# Altium schematic sheet DimmerModule.SchDoc
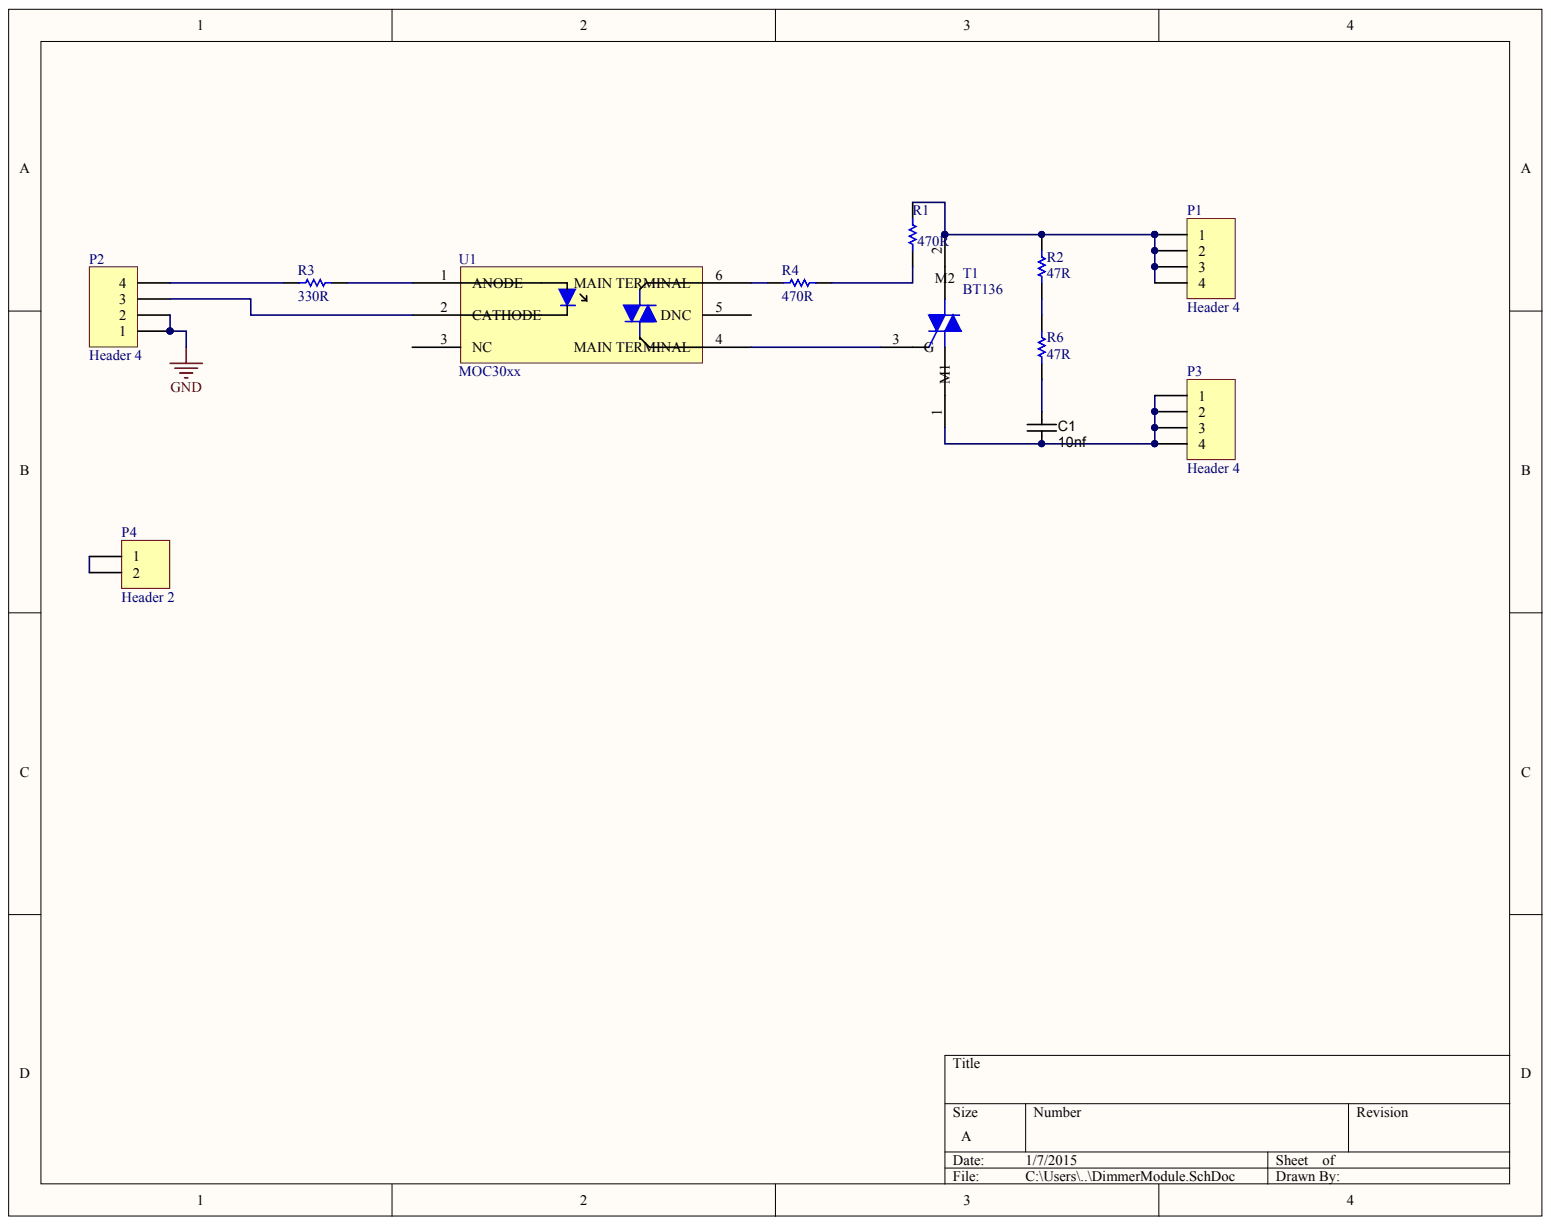
[diagram p0: DimmerModule.SchDoc]

## Parts
C1  10nf
P1  Header 4
P2  Header 4
P3  Header 4
P4  Header 2
R1  470R
R2  47R
R3  330R
R4  470R
R6  47R
T1  BT136
U1  MOC30xx

## Nets
GND: P2.1 P2.2
NetC1_1: C1.1 R6.2
NetC1_2: C1.2 P3.1 P3.2 P3.3 P3.4 T1.1
NetP1_1: P1.1 P1.2 P1.3 P1.4 R1.1 R2.1 T1.2
NetP2_3: P2.3 U1.2(CATHODE)
NetP2_4: P2.4 R3.1
NetP4_1: P4.1 P4.2
NetR1_2: R1.2 R4.2
NetR2_2: R2.2 R6.1
NetR3_2: R3.2 U1.1(ANODE)
NetR4_1: R4.1 U1.6(MAIN_TERMINAL)
NetT1_3: T1.3 U1.4(MAIN_TERMINAL)

Not connected: U1 3(NC) 5(DNC)

## Library data of the parts
C1 = (no symbol name): Manufacturer 1: Wing Fu Technology; Manufacturer Part Number 1: CL21-332-K-400V-7.5; Tolerance: ±10%; Ratings: 400V; Material: Metallized Polyester; Package / Case: 10.5L x 6.0W x 9.0H x 7.5mm Pitch; Value: 10nf
P1-P4 R1-R4 R6 T1 U1 = (no symbol name)
  P1-P4: LatestRevisionDate: 17-Jul-2002; LatestRevisionNote: Re-released for DXP Platform.
  R1-R4 R6: Published: 17-Dec-2003; PackageReference: R6.3x2.3; ComponentLink1Description: Manufacturer Link; ComponentLink1URL: http://www.panasonic.com/industrial/components/resistive_products.htm; ComponentLink2Description: Discontinuation Notice; ComponentLink2URL: http://www.panasonic.com/industrial/components/pdf/dc050.pdf
  P1-P4 R1-R4 R6: Publisher: Altium Limited
